# Altium schematic sheet 022_USB2LAN.SchDoc(022_USB2LAN)
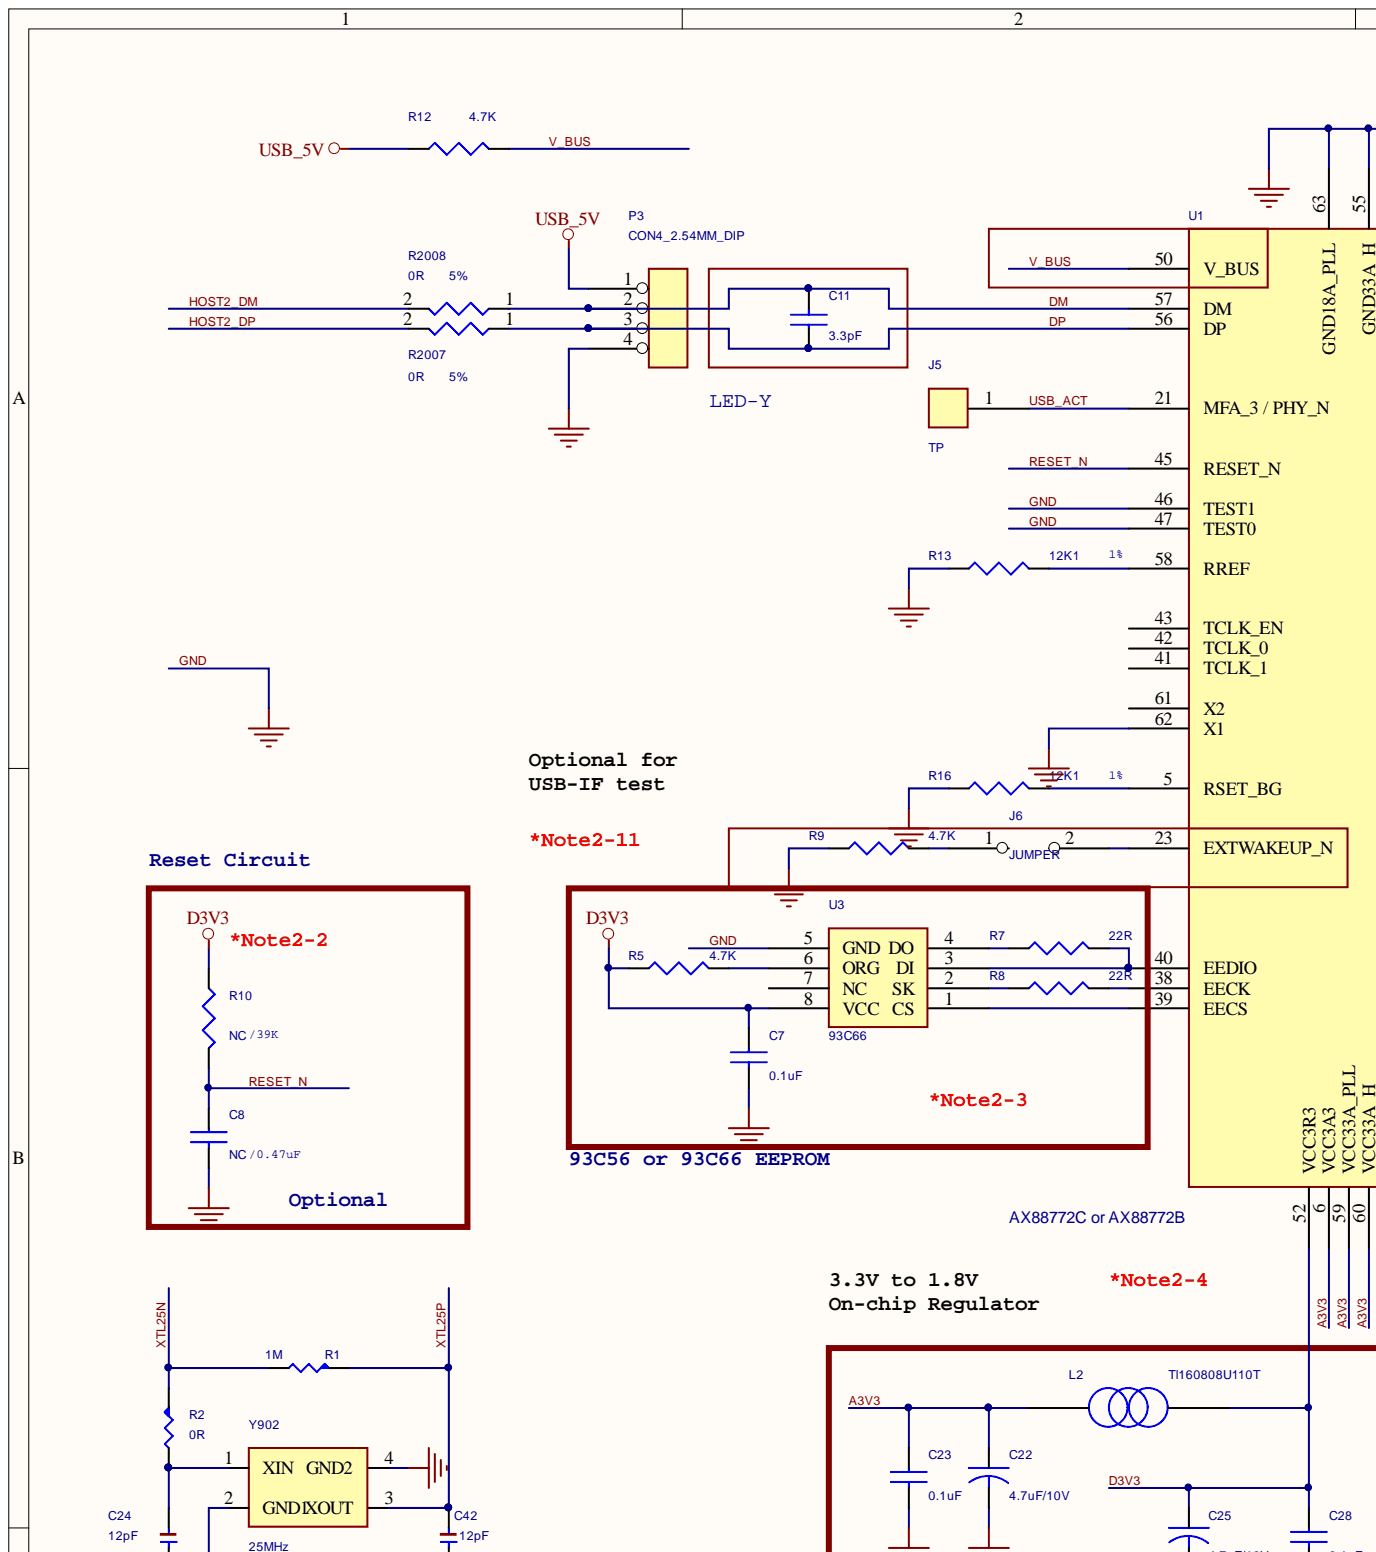
[diagram p0: 022_USB2LAN.SchDoc, top left]
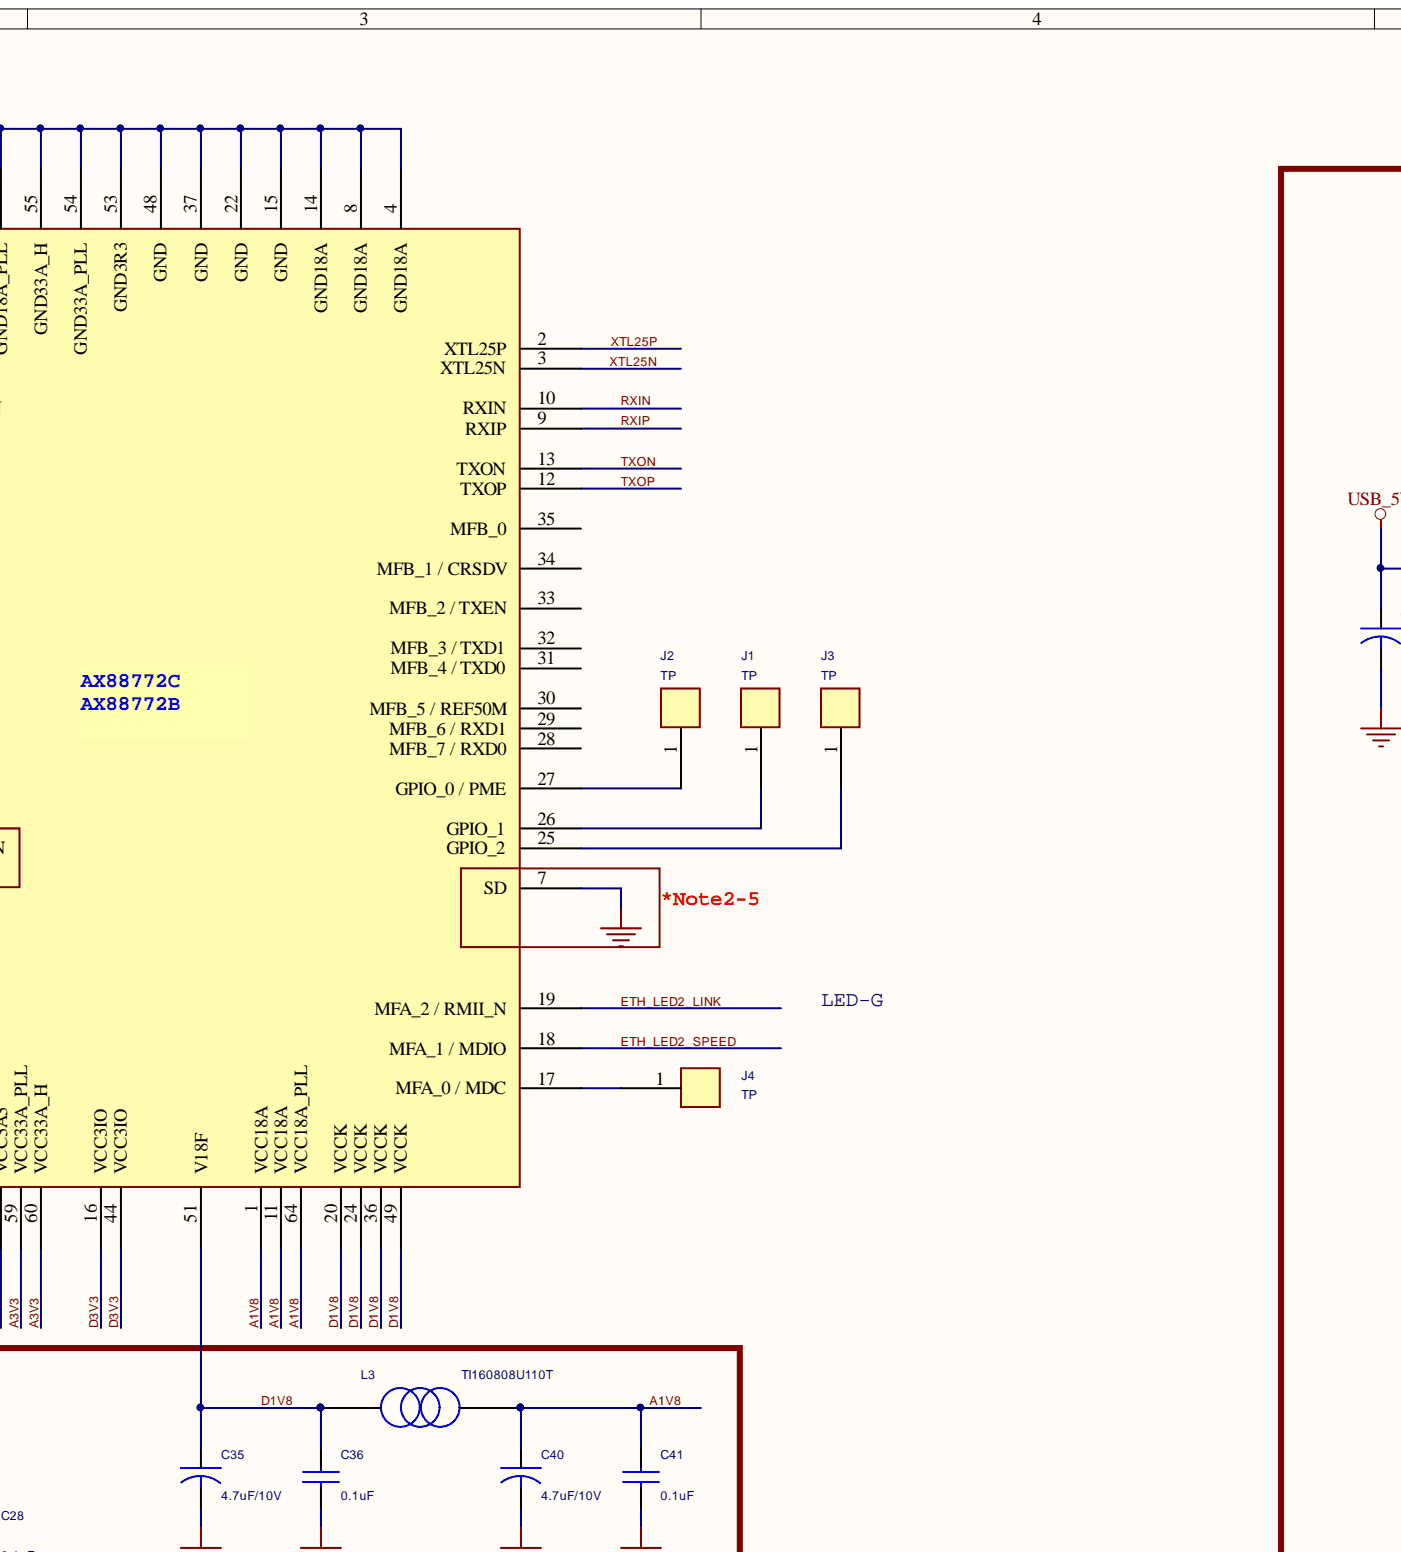
[diagram p1: 022_USB2LAN.SchDoc, top centre]
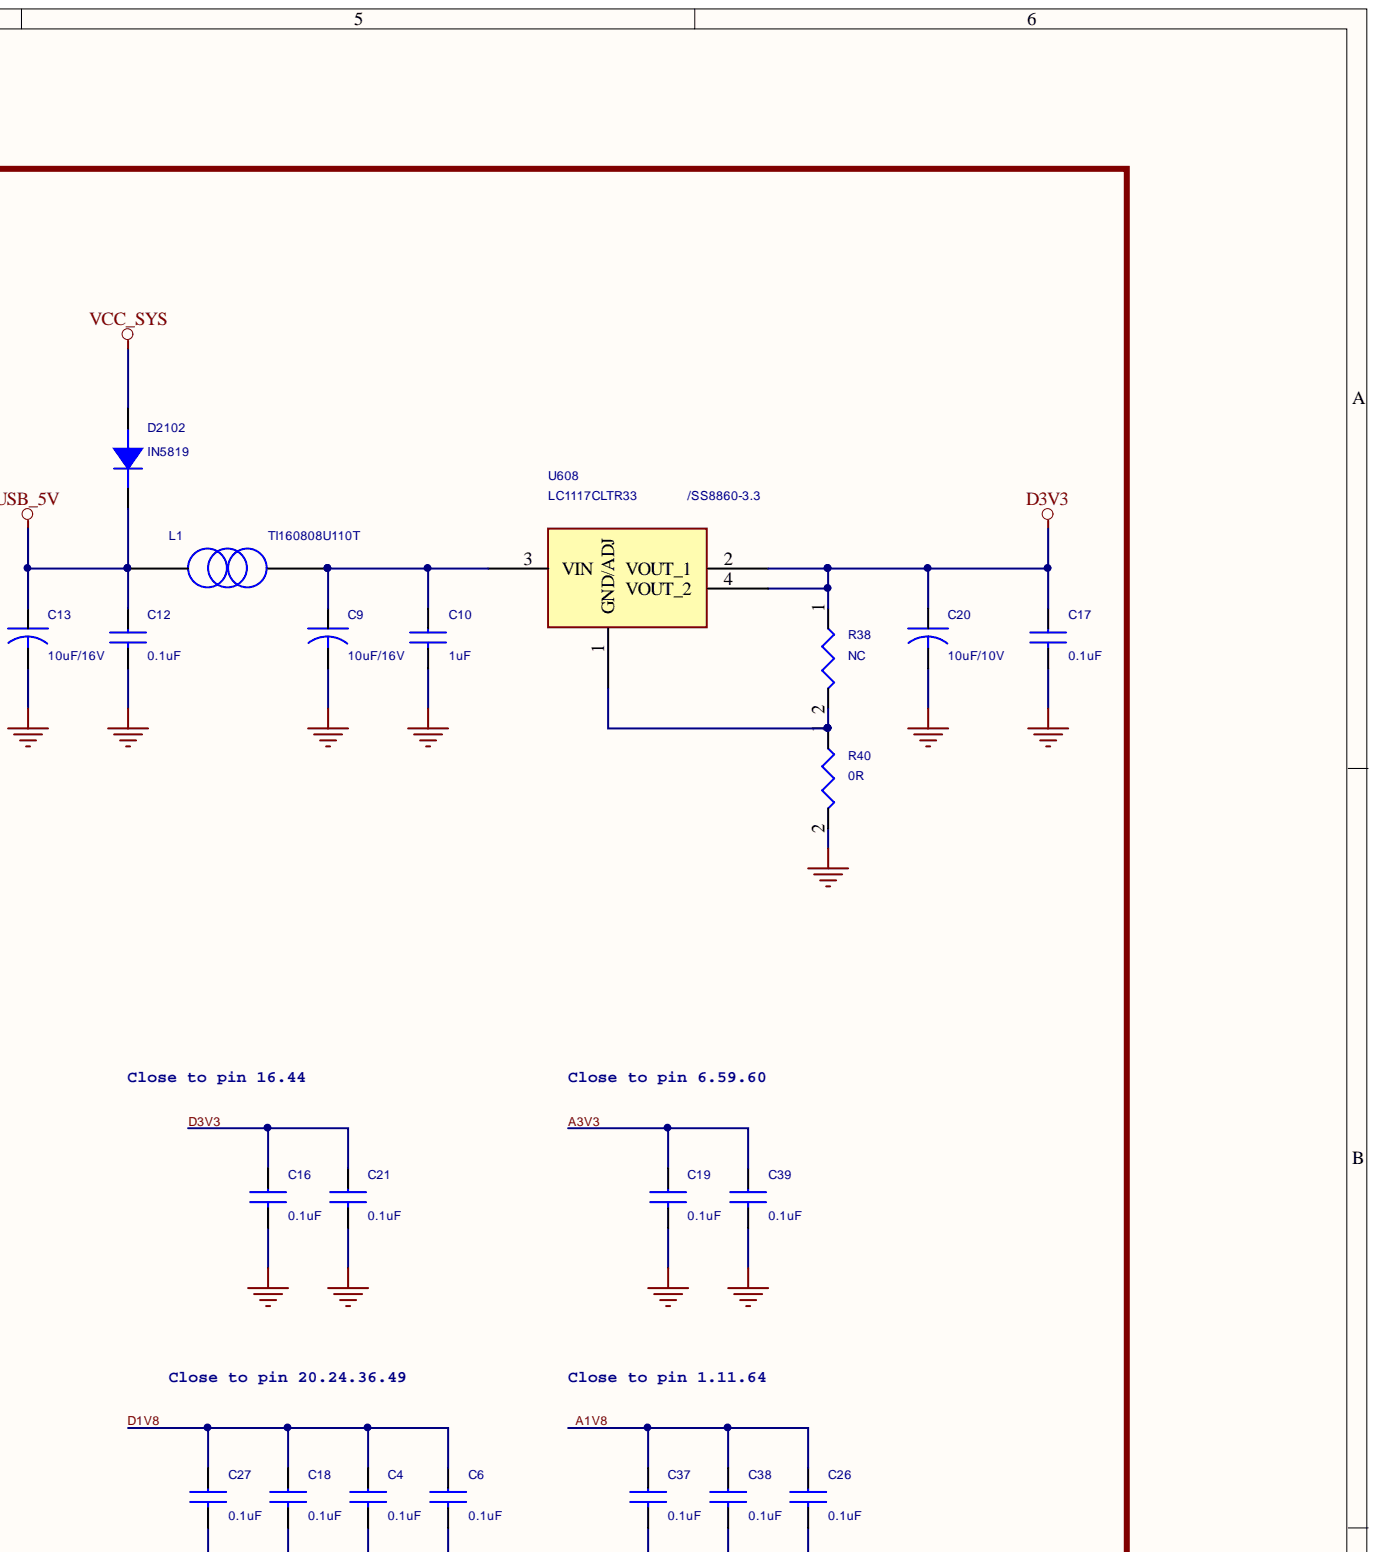
[diagram p2: 022_USB2LAN.SchDoc, top right]
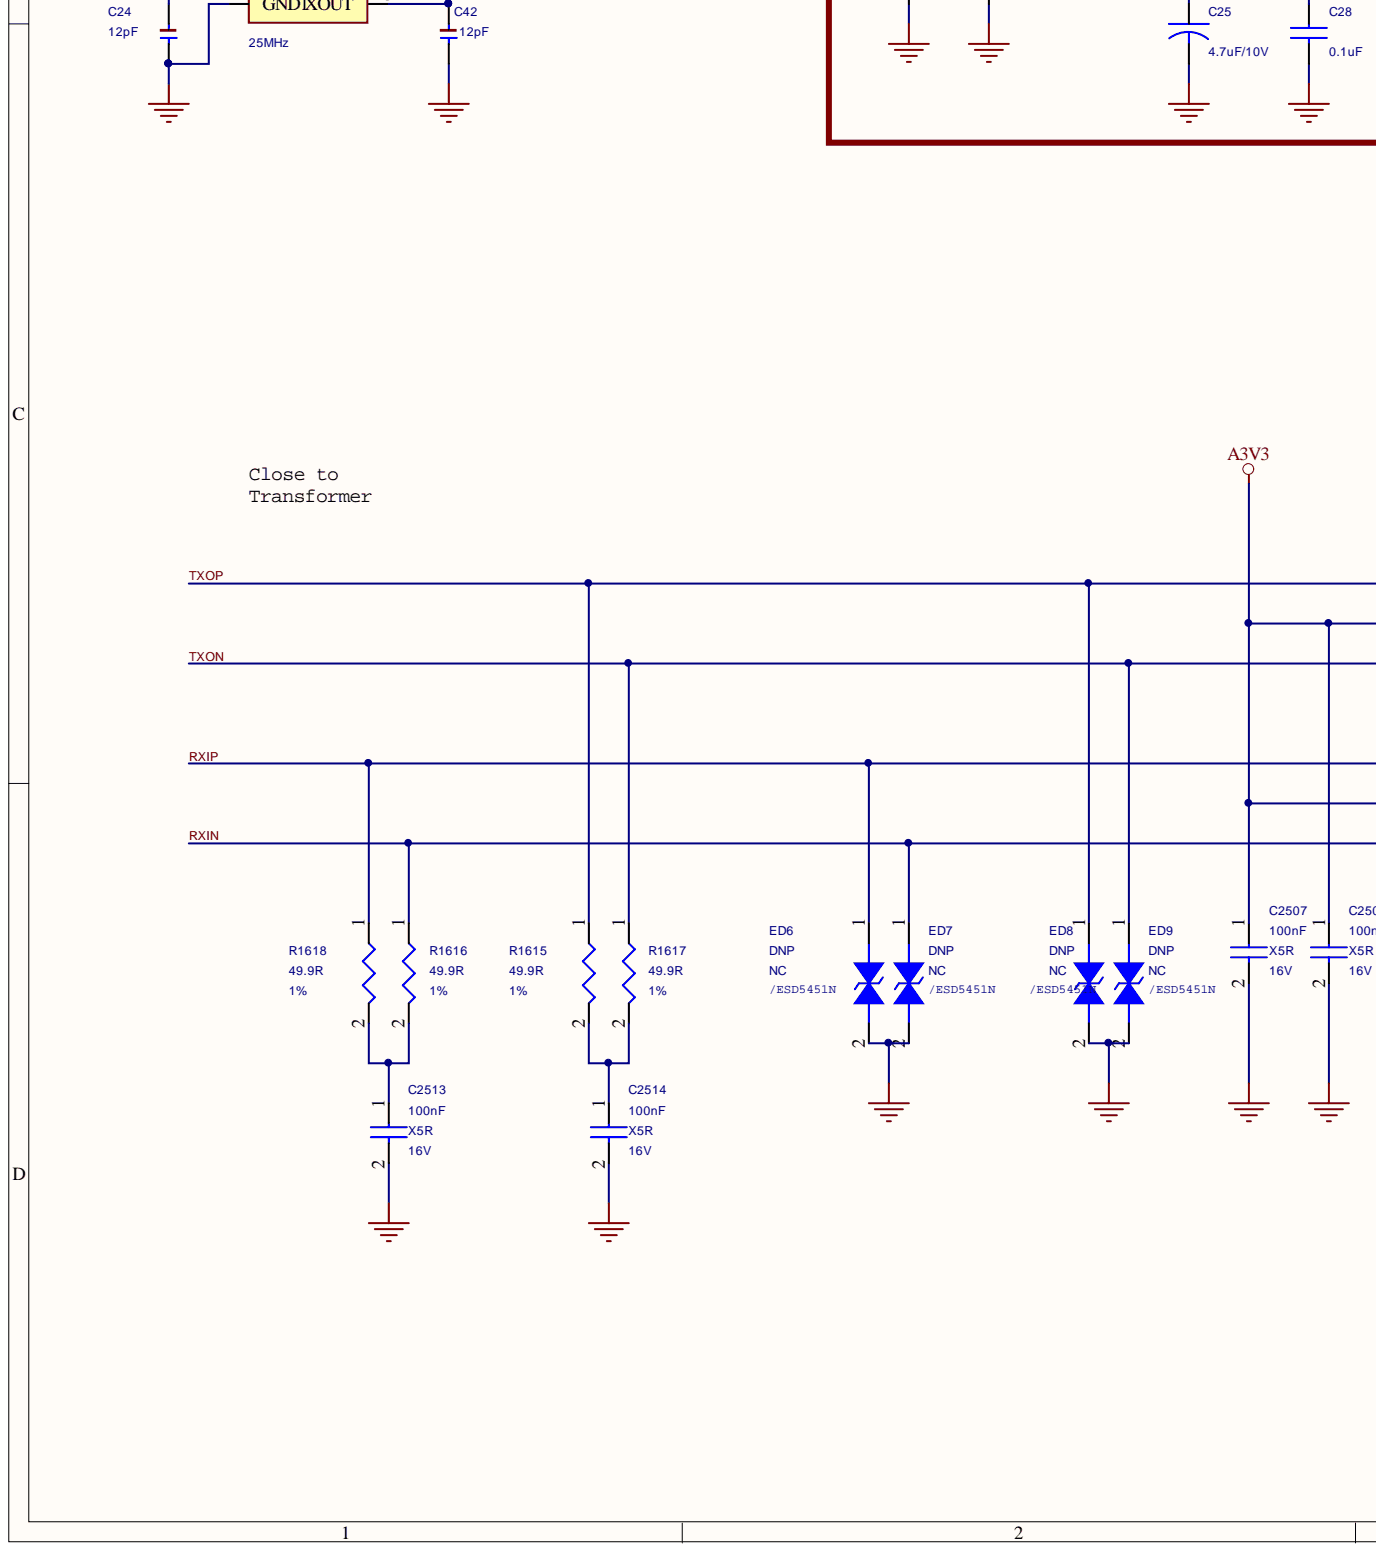
[diagram p3: 022_USB2LAN.SchDoc, bottom left]
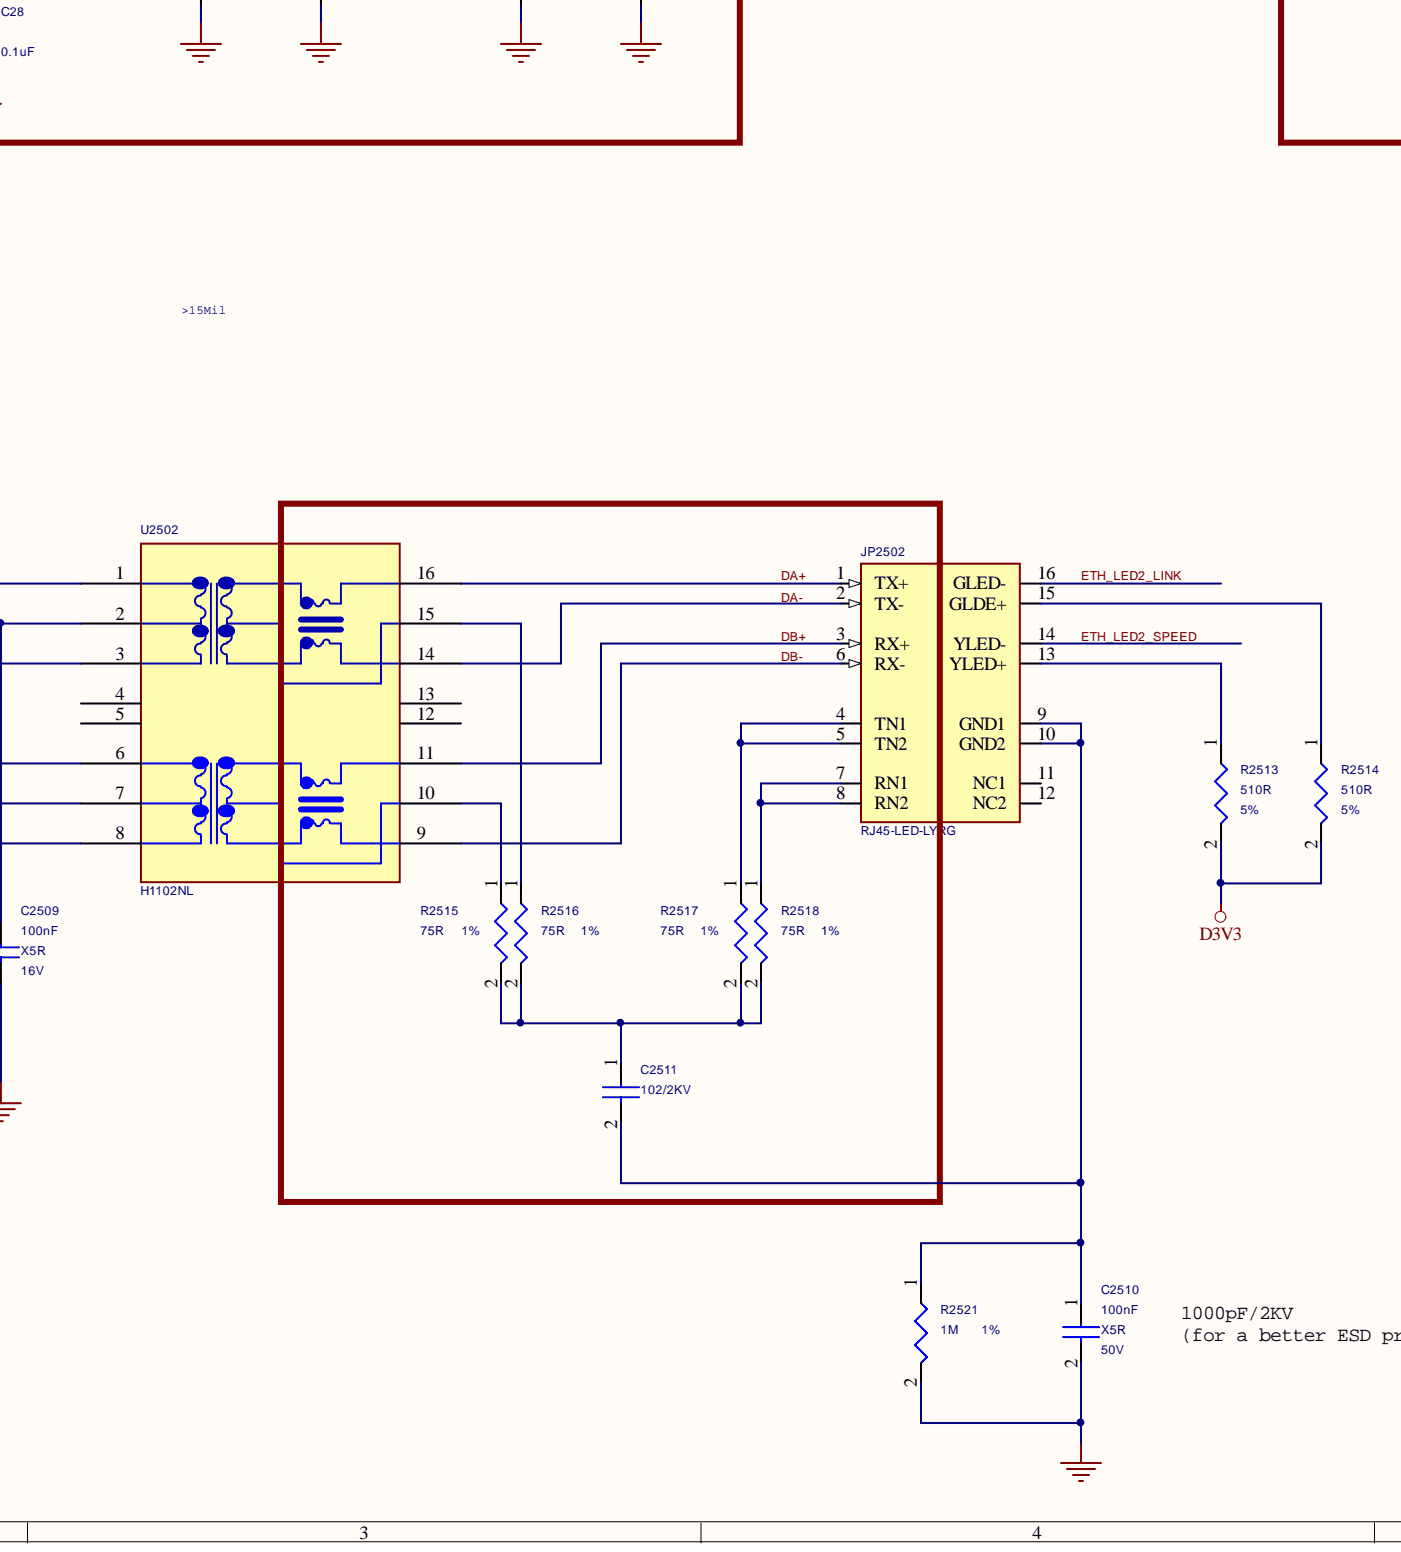
[diagram p4: 022_USB2LAN.SchDoc, bottom centre]
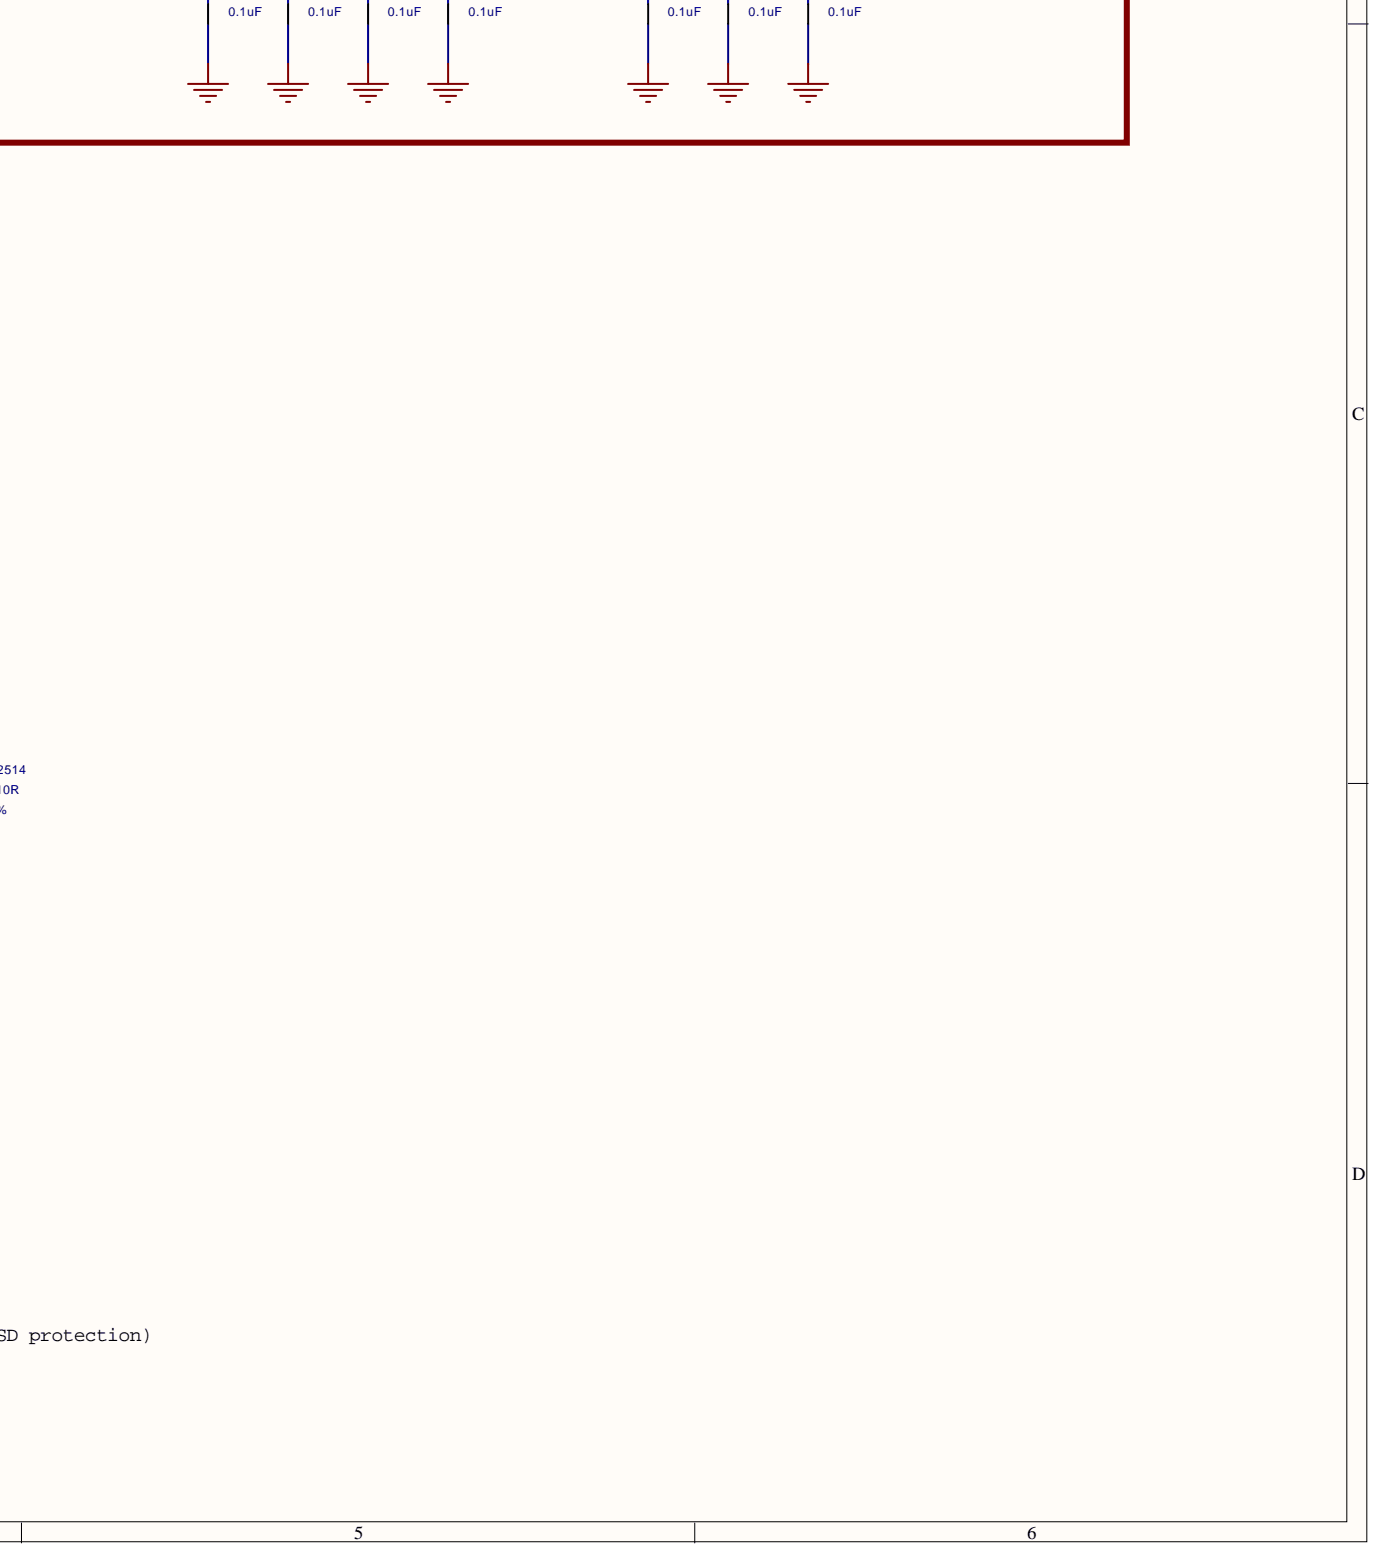
[diagram p5: 022_USB2LAN.SchDoc, bottom right]

## Parts
C4  0.1uF  [C0402]
C6  0.1uF  [C0402]
C7  0.1uF  [C0402]
C8  NC  [C0402]
C9  10uF/16V  [C0603]
C10  1uF  [C0402]
C11  3.3pF  [C0402]
C12  0.1uF  [C0402]
C13  10uF/16V  [C0603]
C16  0.1uF  [C0402]
C17  0.1uF  [C0402]
C18  0.1uF  [C0402]
C19  0.1uF  [C0402]
C20  10uF/10V  [C0603]
C21  0.1uF  [C0402]
C22  4.7uF/10V  [C0603]
C23  0.1uF  [C0402]
C24  12pF  [CCS-0603]
C25  4.7uF/10V  [C0603]
C26  0.1uF  [C0402]
C27  0.1uF  [C0402]
C28  0.1uF  [C0402]
C35  4.7uF/10V  [C0603]
C36  0.1uF  [C0402]
C37  0.1uF  [C0402]
C38  0.1uF  [C0402]
C39  0.1uF  [C0402]
C40  4.7uF/10V  [C0603]
C41  0.1uF  [C0402]
C42  12pF  [CCS-0603]
C2507  100nF  Voltage: 16V  Dielectric: X5R  [C0402]
C2509  100nF  Voltage: 16V  Dielectric: X5R  [C0402]
C2510  100nF  Voltage: 50V  Dielectric: X5R  [C0603]
C2511  102/2KV  [C1206]
C2513  100nF  Voltage: 16V  Dielectric: X5R  [C0402]
C2514  100nF  Voltage: 16V  Dielectric: X5R  [C0402]
D2102  IN5819  [SOD_123]
ED6  NC  OPTION: DNP  [ESD0402]
ED7  NC  OPTION: DNP  [ESD0402]
ED8  NC  OPTION: DNP  [ESD0402]
ED9  NC  OPTION: DNP  [ESD0402]
J1  TP  [TP_0R70]
J2  TP  [TP_0R70]
J3  TP  [TP_0R70]
J4  TP  [TP_0R70]
J5  TP  [TP_0R70]
J6  JUMPER  [HEADER2X2.0]
JP2502  RJ45-LED-LYRG  [RJ45_CON8]
L1  Tl160808U110T  [L0603]
L2  Tl160808U110T  [L0603]
L3  Tl160808U110T  [L0603]
P3  CON4_2.54MM_DIP  [CON4_2.5MM_DIP]
R1  1M  [R0402]
R2  0R  [R0402]
R5  4.7K  [R0402]
R7  22R  [R0402]
R8  22R  [R0402]
R9  4.7K  [R0402]
R10  NC  [R0402]
R12  4.7K  [R0402]
R13  12K1  [R0402]
R16  12K1  [R0402]
R38  NC  [R0402]
R40  0R  [R0402]
R1615  49.9R  Tolerance: 1%  [R0402]
R1616  49.9R  Tolerance: 1%  [R0402]
R1617  49.9R  Tolerance: 1%  [R0402]
R1618  49.9R  Tolerance: 1%  [R0402]
R2007  0R  Tolerance: 5%  [R0402]
R2008  0R  Tolerance: 5%  [R0402]
R2513  510R  Tolerance: 5%  [R0402]
R2514  510R  Tolerance: 5%  [R0402]
R2515  75R  Tolerance: 1%  [R0402]
R2516  75R  Tolerance: 1%  [R0402]
R2517  75R  Tolerance: 1%  [R0402]
R2518  75R  Tolerance: 1%  [R0402]
R2521  1M  Tolerance: 1%  [R1206]
U1  AX88772C or AX88772B  [LQFP64/7X7]
U3  93C66  [TSSOP8\0.65]
U608  LC1117CLTR33  option: /SS8860-3.3  [SOT-223]
U2502  H1102NL  [SOIC16]
Y902  25MHz  [CRY-3225]

## Nets
USB_5V: C12.1 C13.1 D2102.2 L1.1 P3.1 R12.1
VCC_SYS: D2102.1
A1V8: C26.1 C37.1 C38.1 C40.1 C41.1 L3.2 U1.1(VCC18A) U1.11(VCC18A) U1.64(VCC18A_PLL)
A3V3: C19.1 C22.1 C23.1 C39.1 C2507.1 C2509.1 L2.1 U1.6(VCC3A3) U1.59(VCC33A_PLL) U1.60(VCC33A_H) U2502.2 U2502.7
D1V8: C4.1 C6.1 C18.1 C27.1 C35.1 C36.1 L3.1 U1.20(VCCK) U1.24(VCCK) U1.36(VCCK) U1.49(VCCK) U1.51(V18F)
D3V3: C7.1 C16.1 C17.1 C20.1 C21.1 C25.1 C28.1 L2.2 R5.1 R10.1 R38.1 R2513.2 R2514.2 U1.16(VCC3IO) U1.44(VCC3IO) U1.52(VCC3R3) U3.8(VCC) U608.2(VOUT_1) U608.4(VOUT_2)
DA+: JP2502.1(TX+) U2502.16
DA-: JP2502.2(TX-) U2502.14
DB+: JP2502.3(RX+) U2502.11
DB-: JP2502.6(RX-) U2502.9
DM: C11.2 P3.2 R2008.1 U1.57(DM)
DP: C11.1 P3.3 R2007.1 U1.56(DP)
ETH_LED2_LINK: JP2502.16(GLED-) U1.19(MFA_2_/_RMII_N)
ETH_LED2_SPEED: JP2502.14(YLED-) U1.18(MFA_1_/_MDIO)
GND: C4.2 C6.2 C7.2 C8.2 C9.2 C10.2 C12.2 C13.2 C16.2 C17.2 C18.2 C19.2 C20.2 C21.2 C22.2 C23.2 C24.1 C25.2 C26.2 C27.2 C28.2 C35.2 C36.2 C37.2 C38.2 C39.2 C40.2 C41.2 C42.1 C2507.2 C2509.2 C2510.2 C2513.2 C2514.2 ED6.2 ED7.2 ED8.2 ED9.2 P3.4 R9.1 R13.1 R16.1 R40.2 R2521.2 U1.4(GND18A) U1.7(SD) U1.8(GND18A) U1.14(GND18A) U1.15(GND) U1.22(GND) U1.37(GND) U1.46(TEST1) U1.47(TEST0) U1.48(GND) U1.53(GND3R3) U1.54(GND33A_PLL) U1.55(GND33A_H) U1.62(X1) U1.63(GND18A_PLL) U3.5(GND) Y902.2(GND1) Y902.4(GND2)
HOST2_DM: R2008.2
HOST2_DP: R2007.2
RESET_N: C8.1 R10.2 U1.45(RESET_N)
RXIN: ED7.1 R1616.1 U1.10(RXIN) U2502.8
RXIP: ED6.1 R1618.1 U1.9(RXIP) U2502.6
TXON: ED9.1 R1617.1 U1.13(TXON) U2502.3
TXOP: ED8.1 R1615.1 U1.12(TXOP) U2502.1
USB_ACT: J5.1 U1.21(MFA_3_/_PHY_N)
V_BUS: R12.2 U1.50(V_BUS)
XTL25N: R1.2 R2.1 U1.3(XTL25N)
XTL25P: C42.2 R1.1 U1.2(XTL25P) Y902.3(XOUT)
NetC9_1: C9.1 C10.1 L1.2 U608.3(VIN)
NetC24_2: C24.2 R2.2 Y902.1(XIN)
NetC2510_1: C2510.1 C2511.2 JP2502.9(GND1) JP2502.10(GND2) R2521.1
NetC2511_1: C2511.1 R2515.2 R2516.2 R2517.2 R2518.2
NetC2513_1: C2513.1 R1616.2 R1618.2
NetC2514_1: C2514.1 R1615.2 R1617.2
NetJ1_1: J1.1 U1.26(GPIO_1)
NetJ2_1: J2.1 U1.27(GPIO_0_/_PME)
NetJ3_1: J3.1 U1.25(GPIO_2)
NetJ4_1: J4.1 U1.17(MFA_0_/_MDC)
NetJ6_1: J6.1 R9.2
NetJ6_2: J6.2 U1.23(EXTWAKEUP_N)
NetJP2502_4: JP2502.4(TN1) JP2502.5(TN2) R2517.1
NetJP2502_7: JP2502.7(RN1) JP2502.8(RN2) R2518.1
NetJP2502_13: JP2502.13(YLED+) R2513.1
NetJP2502_15: JP2502.15(GLDE+) R2514.1
NetR5_2: R5.2 U3.6(ORG)
NetR7_1: R7.1 U3.4(DO)
NetR7_2: R7.2 U1.40(EEDIO) U3.3(DI)
NetR8_1: R8.1 U3.2(SK)
NetR8_2: R8.2 U1.38(EECK)
NetR13_2: R13.2 U1.58(RREF)
NetR16_2: R16.2 U1.5(RSET_BG)
NetR38_2: R38.2 R40.1 U608.1(GND/ADJ)
NetR2515_1: R2515.1 U2502.10
NetR2516_1: R2516.1 U2502.15
NetU1_39: U1.39(EECS) U3.1(CS)

Not connected: JP2502 11(NC1) 12(NC2); U1 28(MFB_7_/_RXD0) 29(MFB_6_/_RXD1) 30(MFB_5_/_REF50M) 31(MFB_4_/_TXD0) 32(MFB_3_/_TXD1) 33(MFB_2_/_TXEN) 34(MFB_1_/_CRSDV) 35(MFB_0) 41(TCLK_1) 42(TCLK_0) 43(TCLK_EN) 61(X2); U3 7(NC); U2502 4 5 12 13

## Library data of the parts
C4 C6-C8 C18 C26-C28 C36-C38 C41 = CAP NP_Dup1: Component Kind: Standard
C9 C13 C20 C22 C35 = CAP: Component Kind: Standard
C10 C12 C16 C17 C19 C21 C23 C39 = CAP NP: Component Kind: Standard
C11 = C_Dup1: Component Kind: Standard
C24 C42 = C-0603 5%: xGLA pn: 10-00005-01; Component Kind: Standard
C25 C40 = C: Component Kind: Standard
C2507 C2509 C2513 C2514 = CAP NP_Dup5: cap,100.00nF,+/-10%,16V,X5R,C0402; Manufacturer: TDK; Tolerance: 10%; RK PN: C1005X5R1C104K050BA; Priority: A; Number: 37; C_Voltage: 6.3V; Part Type: C0402; Manufacturer PN: C1005X5R1C104K050BA; Component Kind: Standard; Created by: JJJ
C2510 = CAP NP_Dup5: cap,100.00nF,+/-10%,50V,X5R,C0603; Manufacturer: TDK; Tolerance: 10%; RK PN: C1608X5R1H104K080AA; Priority: A; Number: 37; C_Voltage: 6.3V; Part Type: C0603; Manufacturer PN: C1608X5R1H104K080AA; Component Kind: Standard; Created by: JJJ
C2511 = CAP NP_Dup5: cap,10.00uF,+/-10%,50V,X5R,C1206; Manufacturer: TDK; Tolerance: 10%; RK PN: C3216X5R1H106K160AB; Priority: A; Option: DNP; Number: 37; C_Voltage: 6.3V; Voltage: 50V; Part Type: C1206; Manufacturer PN: C3216X5R1H106K160AB; Dielectric: X5R; Component Kind: Standard; Created by: JJJ
D2102 = DIODE: Schottky Barrier?B5819W SOD-123; Manufacturer: 닉롯; ROOM: CHG; RK PN: B5819W; Priority: A; Part Type: Schottky rectifier; Manufacturer PN: B5819W; Component Kind: Standard; Created by: JJJ
ED6-ED9 = DIODE BI_DIR TRIG: 1-Line, Bi-directional, Transient Voltage Suppressors; Manufacturer: WillSemi(甲먀군랄); RK PN: ESD5451N; Priority: A; Part Type: ESD_TVS; Manufacturer PN: ESD5451N; Component Kind: Standard; Created by: JJJ
J1-J5 = CON1: Component Kind: Standard
JP2502 = RJ45_CON8_5: Component Kind: Standard
L1-L3 = L: Component Kind: Standard
P3 = CON4_2.5MM_DIP_Dup1: option: DNP; Component Kind: Standard
R1 R2 = R330-0603 5%: xGLA pn: 13-00009-01; CLASS: IC; BOM: SMD-R 330 (0603) 5%; Component Kind: Standard
R5 R7 R16 = R: Component Kind: Standard
R8-R10 R12 R13 = R_Dup1: Component Kind: Standard
R38 = RESISTOR_Dup1: 哥棨슌훡롯量,150K,+/-1%,R0402,1/16W.; Manufacturer: YAGEO; Wattage: 1/16W; Tolerance: 1%; RK PN: RC0402FR-07150KL; Priority: A; Part Type: 哥棨슌훡롯量; Manufacturer PN: RC0402FR-07150KL; Component Kind: Standard; Created by: YTL
R40 = RESISTOR_Dup1: 哥棨슌훡롯量,33K,+/-1%,R0402,1/16W.; Manufacturer: YAGEO; Wattage: 1/16W; Tolerance: 1%; RK PN: RC0402FR-0733KL; Priority: A; Part Type: 哥棨슌훡롯量; Manufacturer PN: RC0402FR-0733KL; Component Kind: Standard; Created by: YTL
R1615-R1618 = RESISTOR_Dup1: 哥棨슌훡롯量,49R9,+/-1%,R0603,1/10W.; Manufacturer: YAGEO; Wattage: 1/10W; RK PN: RC0603FR-0749R9L; Priority: A; Part Type: 哥棨슌훡롯量; Manufacturer PN: RC0603FR-0749R9L; Component Kind: Standard; Created by: YTL
R2007 R2008 = RESISTOR_Dup1: 哥棨슌훡롯量,0R,+/-5%,R0603,1/10W.; Manufacturer: YAGEO; Wattage: 1/10W; RK PN: RC0603JR-070RL; Priority: A; Part Type: 哥棨슌훡롯量; Manufacturer PN: RC0603JR-070RL; Component Kind: Standard; Created by: YTL
R2513 R2514 = RESISTOR_Dup1: 哥棨슌훡롯量,510R,+/-5%,R0402,1/16W.; Manufacturer: YAGEO; Wattage: 1/16W; RK PN: RC0402JR-07510RL; Priority: A; Part Type: 哥棨슌훡롯量; Manufacturer PN: RC0402JR-07510RL; Component Kind: Standard; Created by: YTL
R2515-R2518 R2521 = RESISTOR_Dup1: 哥棨슌훡롯量,75R,+/-1%,R0603,1/10W.; Manufacturer: YAGEO; Wattage: 1/10W; RK PN: RC0603FR-0775RL; Priority: A; Part Type: 哥棨슌훡롯量; Manufacturer PN: RC0603FR-0775RL; Component Kind: Standard; Created by: YTL
U1 = AX88772B_30: Component Kind: Standard
U3 = 93c66_0: Component Kind: Standard
U608 = LDO_AMS1117: Output 800mA LDO.Fixed Voltage 3.3V.; Manufacturer: Advanced Monolithic Systems; RK PN: AMS1117-3.3; Priority: A; Part Type: LDO; Manufacturer PN: AMS1117-3.3; Component Kind: Standard; Created by: JJJ
U2502 = LAN1102: Component Kind: Standard
Y902 = CRYSTAL_4P: 乞胱춥導?24M?3225,˙10ppm?8pF?Hosonic; Manufacturer: Hosonic(숨僑롯朗); RK PN: E3SB24.0000F08E11; Priority: A; Part Type: CRYSTAL; Manufacturer PN: E3SB24.0000F08E11; Component Kind: Standard; Created by: JJJ
J6 = JUMPER: Component Kind: Standard
